# Altium schematic sheet Inresol_grid_inverter_Main.SchDoc(Inresol_grid_inverter_Main)
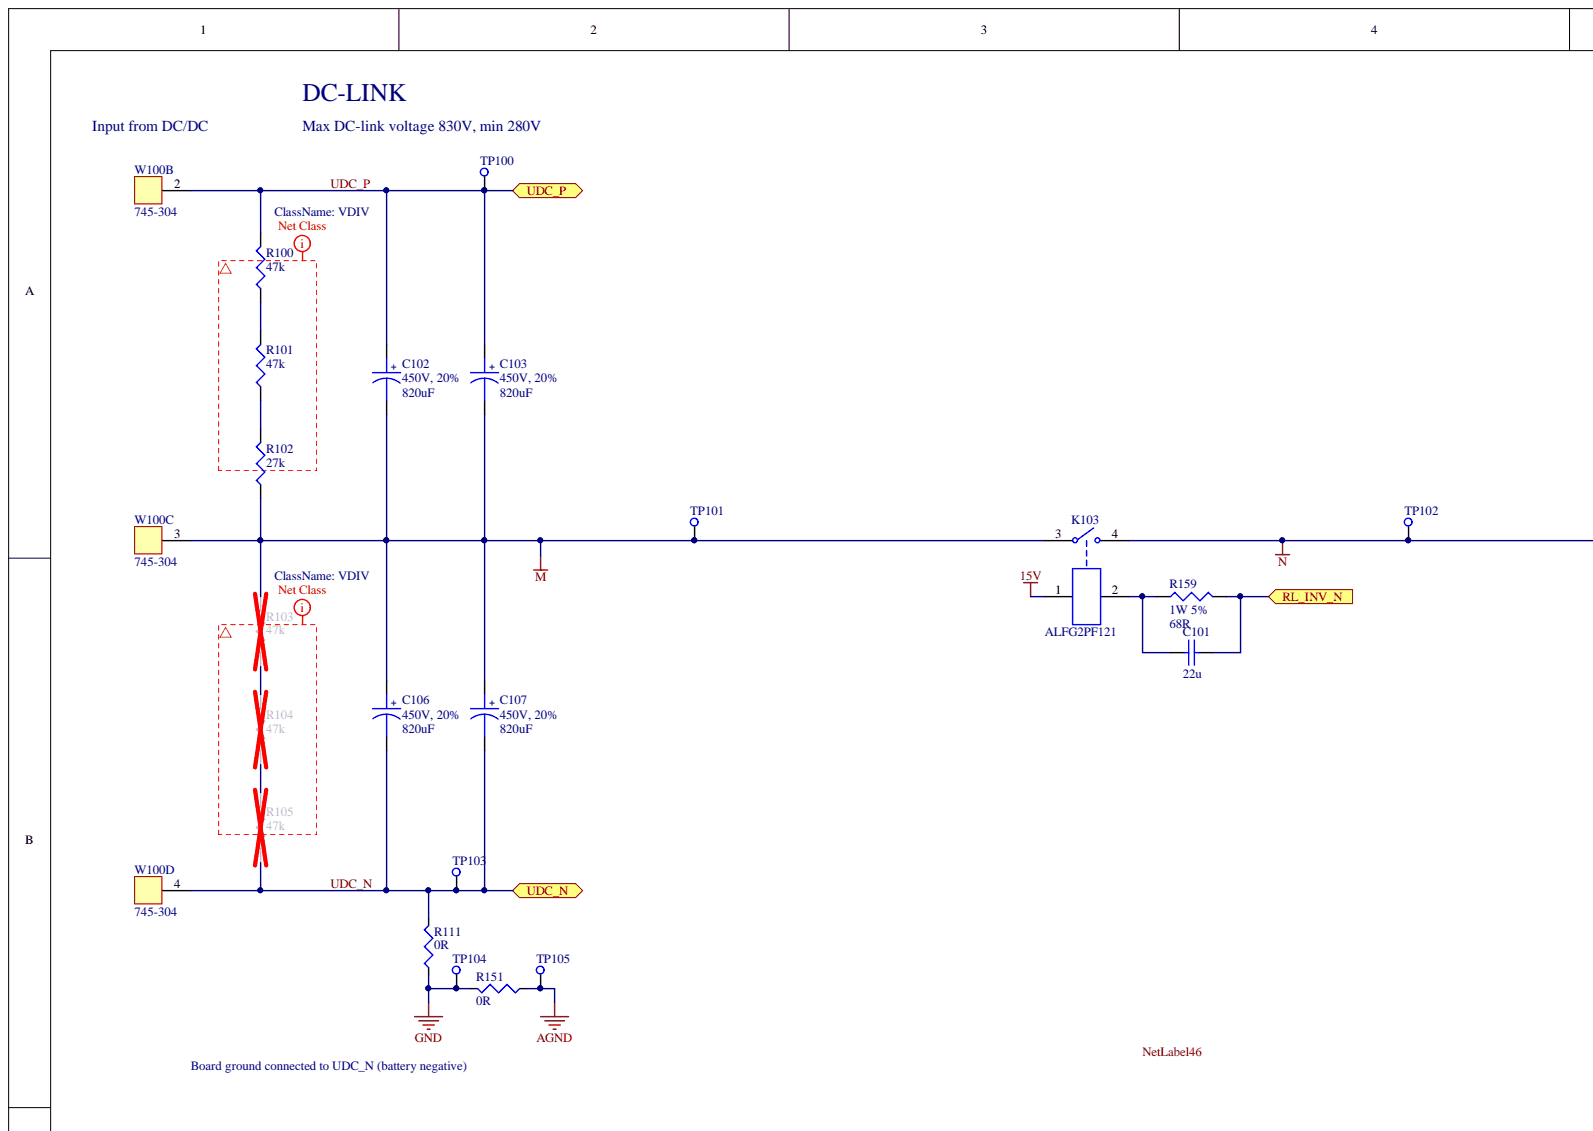
[diagram p0: Inresol_grid_inverter_Main.SchDoc, top left]
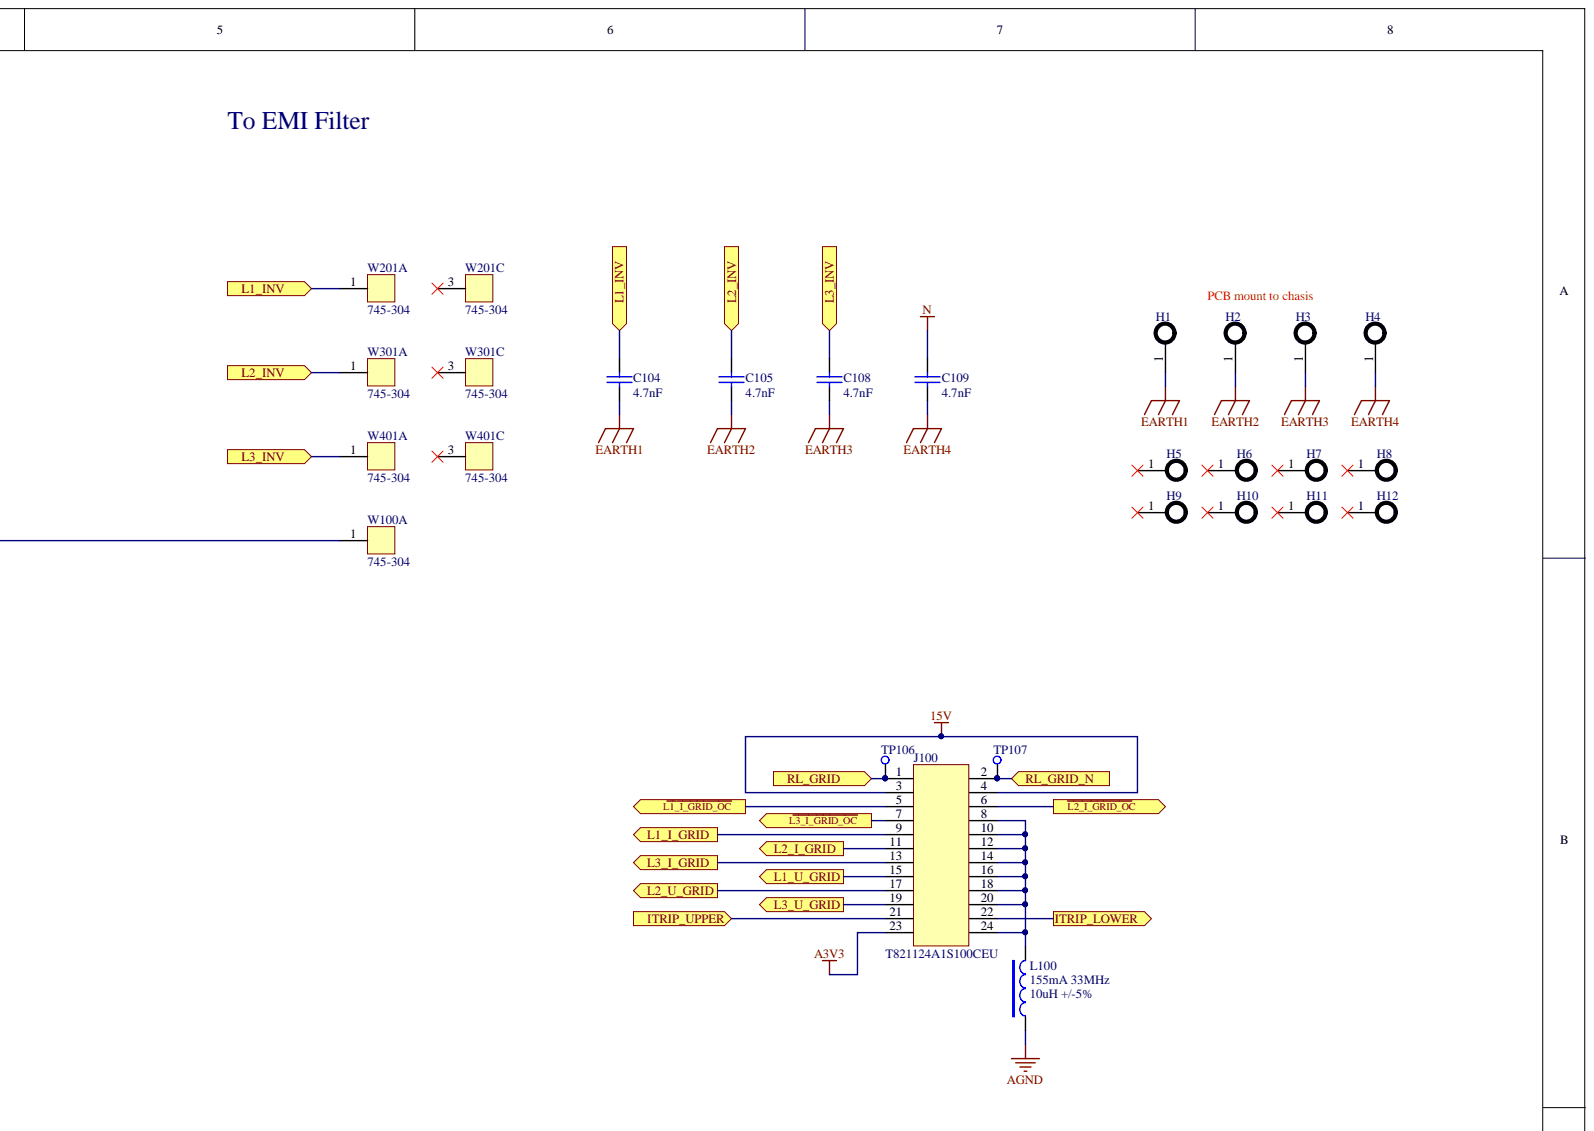
[diagram p1: Inresol_grid_inverter_Main.SchDoc, top right]
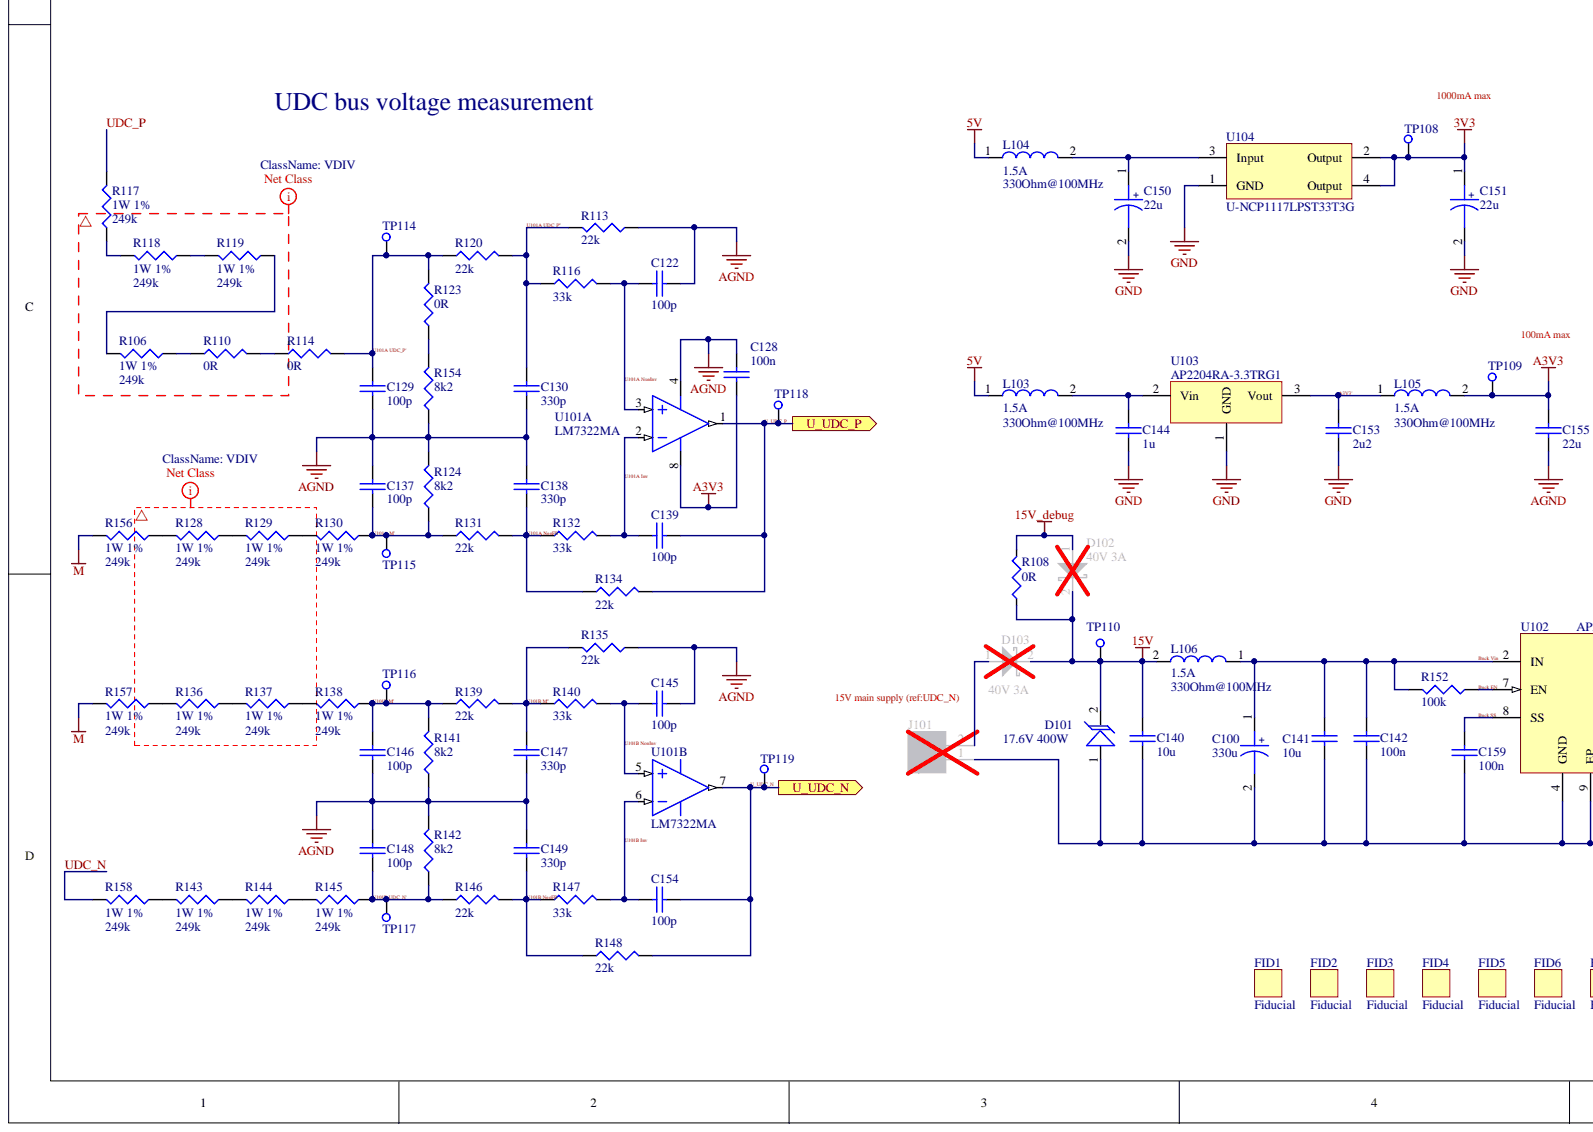
[diagram p2: Inresol_grid_inverter_Main.SchDoc, bottom left]
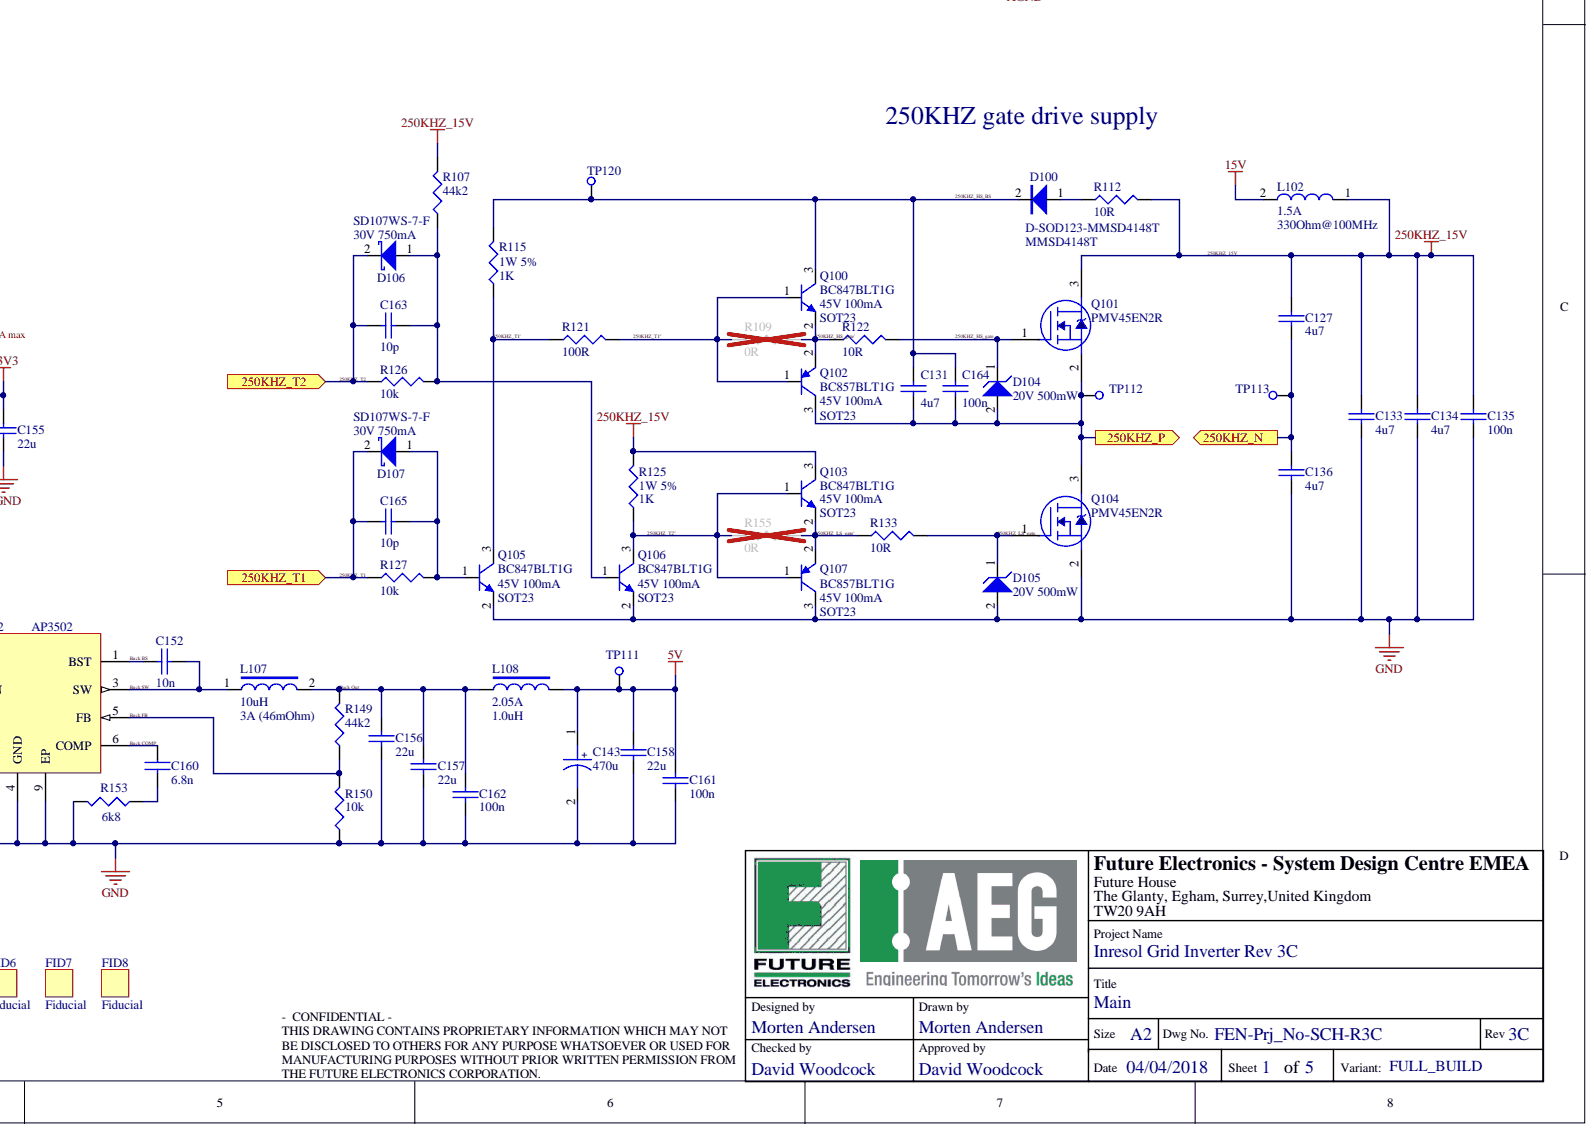
[diagram p3: Inresol_grid_inverter_Main.SchDoc, bottom right]

## Parts
C100  value 330u  [C-SM_R8x10]  (hidden comment: C-330uF-25V-Elec-8x10-EMEA-06-09-2017)
C101  value 22u  [1210]  (hidden comment: C-22uF-25V-Cer-1210)
C102  value 820uF  Rating: 450V, 20%  [C-R40x60_4pin_Snap-In]  (hidden comment: C-820uF-450V-Elec-40x60-EMEA-19-10-2016)
C103  value 820uF  Rating: 450V, 20%  [C-R40x60_4pin_Snap-In]  (hidden comment: C-820uF-450V-Elec-40x60-EMEA-19-10-2016)
C104  value 4.7nF  [C-12.5x5_LS10]  (hidden comment: C-4.7nF-300V-Y2)
C105  value 4.7nF  [C-12.5x5_LS10]  (hidden comment: C-4.7nF-300V-Y2)
C106  value 820uF  Rating: 450V, 20%  [C-R40x60_4pin_Snap-In]  (hidden comment: C-820uF-450V-Elec-40x60-EMEA-19-10-2016)
C107  value 820uF  Rating: 450V, 20%  [C-R40x60_4pin_Snap-In]  (hidden comment: C-820uF-450V-Elec-40x60-EMEA-19-10-2016)
C108  value 4.7nF  [C-12.5x5_LS10]  (hidden comment: C-4.7nF-300V-Y2)
C109  value 4.7nF  [C-12.5x5_LS10]  (hidden comment: C-4.7nF-300V-Y2)
C122  value 100p  [0603]  (hidden comment: C-100pF-25V-Cer-0603)
C127  value 4u7  [1206]  (hidden comment: C-4.7uF-50V-Cer-1206-emea-8-10-15)
C128  value 100n  [0603]  (hidden comment: C-100nF-50V-Cer-0603)
C129  value 100p  [0603]  (hidden comment: C-100pF-25V-Cer-0603)
C130  value 330p  [0603]  (hidden comment: C-330pF-25V-Cer-0603)
C131  value 4u7  [1206]  (hidden comment: C-4.7uF-50V-Cer-1206-emea-8-10-15)
C133  value 4u7  [1206]  (hidden comment: C-4.7uF-50V-Cer-1206-emea-8-10-15)
C134  value 4u7  [1206]  (hidden comment: C-4.7uF-50V-Cer-1206-emea-8-10-15)
C135  value 100n  [0603]  (hidden comment: C-100nF-50V-Cer-0603)
C136  value 4u7  [1206]  (hidden comment: C-4.7uF-50V-Cer-1206-emea-8-10-15)
C137  value 100p  [0603]  (hidden comment: C-100pF-25V-Cer-0603)
C138  value 330p  [0603]  (hidden comment: C-330pF-25V-Cer-0603)
C139  value 100p  [0603]  (hidden comment: C-100pF-25V-Cer-0603)
C140  value 10u  [1206]  (hidden comment: C-10uF-50V-Cer-1206)
C141  value 10u  [1206]  (hidden comment: C-10uF-50V-Cer-1206)
C142  value 100n  [0603]  (hidden comment: C-100nF-50V-Cer-0603)
C143  value 470u  [C-SM_R8x10]  (hidden comment: C-470uF-16V-Elec-8x10-EMEA-28-10-2016)
C144  value 1u  [0603]  (hidden comment: C-1uF-25V-Cer-0603)
C145  value 100p  [0603]  (hidden comment: C-100pF-25V-Cer-0603)
C146  value 100p  [0603]  (hidden comment: C-100pF-25V-Cer-0603)
C147  value 330p  [0603]  (hidden comment: C-330pF-25V-Cer-0603)
C148  value 100p  [0603]  (hidden comment: C-100pF-25V-Cer-0603)
C149  value 330p  [0603]  (hidden comment: C-330pF-25V-Cer-0603)
C150  value 22u  [1210(C3225)L-Tant]  (hidden comment: C-22uF-16V-Tant-1210)
C151  value 22u  [1210(C3225)L-Tant]  (hidden comment: C-22uF-16V-Tant-1210)
C152  value 10n  [1206]  (hidden comment: C-10nF-50V-Cer-1206)
C153  value 2u2  [0805]  (hidden comment: C-2.2uF-25V-Cer-0805-emea-8-10-15)
C154  value 100p  [0603]  (hidden comment: C-100pF-25V-Cer-0603)
C155  value 22u  [1206]  (hidden comment: C-22uF-10V-Cer-1206)
C156  value 22u  [1206]  (hidden comment: C-22uF-10V-Cer-1206)
C157  value 22u  [1206]  (hidden comment: C-22uF-10V-Cer-1206)
C158  value 22u  [1206]  (hidden comment: C-22uF-10V-Cer-1206)
C159  value 100n  [0603]  (hidden comment: C-100nF-50V-Cer-0603)
C160  value 6.8n  [0603]  (hidden comment: C-6.8nF-50V-Cer-0603-EMEA-21-07-16)
C161  value 100n  [0603]  (hidden comment: C-100nF-50V-Cer-0603)
C162  value 100n  [0603]  (hidden comment: C-100nF-50V-Cer-0603)
C163  value 10p  [0603]  (hidden comment: C-10pF-10V-Cer-0603)
C164  value 100n  [0603]  (hidden comment: C-100nF-50V-Cer-0603)
C165  value 10p  [0603]  (hidden comment: C-10pF-10V-Cer-0603)
D100  D-SOD123-MMSD4148T  value MMSD4148T  [SOD123]
D101  D-SMA-SMAJ15A-EMEA-06-09-2017  [SMA]  (value not drawn)
D102  Rating: 40V 3A  [SMA]  (hidden comment: D-SMA-MBRA340T3G)
D103  Rating: 40V 3A  [SMA]  (hidden comment: D-SMA-MBRA340T3G)
D104  Rating: 20V 500mW  [SOD123]  (hidden comment: D-SOD123-MMSZ5250B-20V-EMEA-19-05-16)
D105  Rating: 20V 500mW  [SOD123]  (hidden comment: D-SOD123-MMSZ5250B-20V-EMEA-19-05-16)
D106  Rating: 30V 750mA  [SOD323]  (hidden comment: D-SOD323-SD107WS-7-F)
D107  MFR PN: SD107WS-7-F  Rating: 30V 750mA  [SOD323]  (hidden comment: D-SOD323-SD107WS-7-F)
FID1  W-Fiducial-1mm-emea-21-7-15  [Fiducial]  (value not drawn)
FID2  W-Fiducial-1mm-emea-21-7-15  [Fiducial]  (value not drawn)
FID3  W-Fiducial-1mm-emea-21-7-15  [Fiducial]  (value not drawn)
FID4  W-Fiducial-1mm-emea-21-7-15  [Fiducial]  (value not drawn)
FID5  W-Fiducial-1mm-emea-21-7-15  [Fiducial]  (value not drawn)
FID6  W-Fiducial-1mm-emea-21-7-15  [Fiducial]  (value not drawn)
FID7  W-Fiducial-1mm-emea-21-7-15  [Fiducial]  (value not drawn)
FID8  W-Fiducial-1mm-emea-21-7-15  [Fiducial]  (value not drawn)
H1  W-Mounting Hole-non plated-M4  [W-THRU-HOLE-non plated-M4]  (value not drawn)  (no BOM)
H2  W-Mounting Hole-non plated-M4  [W-THRU-HOLE-non plated-M4]  (value not drawn)  (no BOM)
H3  W-Mounting Hole-non plated-M4  [W-THRU-HOLE-non plated-M4]  (value not drawn)  (no BOM)
H4  W-Mounting Hole-non plated-M4  [W-THRU-HOLE-non plated-M4]  (value not drawn)  (no BOM)
H5  W-Mounting Hole-non plated-M4  [W-THRU-HOLE-non plated-M4]  (value not drawn)  (no BOM)
H6  W-Mounting Hole-non plated-M4  [W-THRU-HOLE-non plated-M4]  (value not drawn)  (no BOM)
H7  W-Mounting Hole-non plated-M4  [W-THRU-HOLE-non plated-M4]  (value not drawn)  (no BOM)
H8  W-Mounting Hole-non plated-M4  [W-THRU-HOLE-non plated-M4]  (value not drawn)  (no BOM)
H9  W-Mounting Hole-non plated-M4  [W-THRU-HOLE-non plated-M4]  (value not drawn)  (no BOM)
H10  W-Mounting Hole-non plated-M4  [W-THRU-HOLE-non plated-M4]  (value not drawn)  (no BOM)
H11  W-Mounting Hole-non plated-M4  [W-THRU-HOLE-non plated-M4]  (value not drawn)  (no BOM)
H12  W-Mounting Hole-non plated-M4  [W-THRU-HOLE-non plated-M4]  (value not drawn)  (no BOM)
J100  MFR PN: T821124A1S100CEU  [HDR100-2X10-TH-KEYED]  (hidden comment: J-HDR100-2x12-TH-KEYED)
J101  J-5.08MM-TERMINAL-2-WAY-282837-2-4-9-15EMEA  [TERMINAL-100MIL-4PIN-EMEA]  (value not drawn)
K103  MFR PN: ALFG2PF121  (hidden comment: K-Panasonic-Relay-SPST-NO-35A-12V-EMEA-07-09-2017)
L100  value 10uH +/-5%  Rating: 155mA 33MHz  [2.5x2mm]  (hidden comment: L-IND2.5X2-10uH-155mA-EMEA_20122017)
L102  value 330Ohm@100MHz  Rating: 1.5A  [0805[2012]]  (hidden comment: 330 Ohm 1.5A)
L103  value 330Ohm@100MHz  Rating: 1.5A  [0805[2012]]  (hidden comment: 330 Ohm 1.5A)
L104  value 330Ohm@100MHz  Rating: 1.5A  [0805[2012]]  (hidden comment: 330 Ohm 1.5A)
L105  value 330Ohm@100MHz  Rating: 1.5A  [0805[2012]]  (hidden comment: 330 Ohm 1.5A)
L106  value 330Ohm@100MHz  Rating: 1.5A  [0805[2012]]  (hidden comment: 330 Ohm 1.5A)
L107  value 10uH  Rating: 3A (46mOhm)  [INDSMD6x6]  (hidden comment: L-INDSMD6X6-10uH_3A)
L108  value 1.0uH  Rating: 2.05A  [tbd]  (hidden comment: L-IND30X30-1uH-2.05A-emea-12-11-15)
Q100  value BC847BLT1G  MFR PN: BC847BLT1G  [SOT23]  (hidden comment: Q-SOT23-BC847BLT1G)
Q101  MFR PN: PMV45EN2R  [SOT23]  (hidden comment: Q-PMV45EN2R)
Q102  value BC857BLT1G  MFR PN: BC857BLT1G  Rating: 45V 100mA  [SOT23]  (hidden comment: Q-SOT23-BC857BLT1G)
Q103  value BC847BLT1G  MFR PN: BC847BLT1G  Rating: 45V 100mA  [SOT23]  (hidden comment: Q-SOT23-BC847BLT1G)
Q104  MFR PN: PMV45EN2R  [SOT23]  (hidden comment: Q-PMV45EN2R)
Q105  value BC847BLT1G  MFR PN: BC847BLT1G  Rating: 45V 100mA  [SOT23]  (hidden comment: Q-SOT23-BC847BLT1G)
Q106  value BC847BLT1G  MFR PN: BC847BLT1G  Rating: 45V 100mA  [SOT23]  (hidden comment: Q-SOT23-BC847BLT1G)
Q107  value BC857BLT1G  MFR PN: BC857BLT1G  Rating: 45V 100mA  [SOT23]  (hidden comment: Q-SOT23-BC857BLT1G)
R100  value 47k  [2512]  (hidden comment: R-47k-1W-2512-EMEA-07-09-2017)
R101  value 47k  [2512]  (hidden comment: R-47k-1W-2512-EMEA-07-09-2017)
R102  value 27k  [2512]  (hidden comment: R-27k-1W-2512-EMEA-07-09-2017)
R103  value 47k  [2512]  (hidden comment: R-47k-1W-2512-EMEA-07-09-2017)
R104  value 47k  [2512]  (hidden comment: R-47k-1W-2512-EMEA-07-09-2017)
R105  value 47k  [2512]  (hidden comment: R-47k-1W-2512-EMEA-07-09-2017)
R106  value 249k  Rating: 1W 1%  [2512]  (hidden comment: R-249k-1W-2512)
R107  value 44k2  [0603]  (hidden comment: R-44.2k-100mW -0603)
R108  value 0R  [1206]  (hidden comment: R-0R-250mW-1206)
R109  value 0R  [0603]  (hidden comment: R-0R-100mW-0603)
R110  value 0R  [2512]  (hidden comment: R-0R-1W-2512)
R111  value 0R  [1206]  (hidden comment: R-0R-250mW-1206)
R112  value 10R  [2512]  (hidden comment: R-10R-1W-2512-EMEA-07-09-2017)
R113  value 22k  [0603]  (hidden comment: R-22k-100mW-0603)
R114  value 0R  [2512]  (hidden comment: R-0R-1W-2512)
R115  value 1K  Rating: 1W 5%  [2512]  (hidden comment: R-1K-1W-2512-EMEA)
R116  value 33k  [0603]  (hidden comment: R-33k-100mW-0603)
R117  value 249k  Rating: 1W 1%  [2512]  (hidden comment: R-249k-1W-2512)
R118  value 249k  Rating: 1W 1%  [2512]  (hidden comment: R-249k-1W-2512)
R119  value 249k  Rating: 1W 1%  [2512]  (hidden comment: R-249k-1W-2512)
R120  value 22k  [0603]  (hidden comment: R-22k-100mW-0603)
R121  value 100R  [0603]  (hidden comment: R-100R-100mW-0603)
R122  value 10R  [2512]  (hidden comment: R-10R-1W-2512-EMEA-07-09-2017)
R123  value 0R  [0603]  (hidden comment: R-0R-100mW-0603)
R124  value 8k2  [0603]  (hidden comment: R-8.2k-100mW-0603)
R125  value 1K  Rating: 1W 5%  [2512]  (hidden comment: R-1K-1W-2512-EMEA)
R126  value 10k  [0603]  (hidden comment: R-10k-100mW-0603)
R127  value 10k  [0603]  (hidden comment: R-10k-100mW-0603)
R128  value 249k  Rating: 1W 1%  [2512]  (hidden comment: R-249k-1W-2512)
R129  value 249k  Rating: 1W 1%  [2512]  (hidden comment: R-249k-1W-2512)
R130  value 249k  Rating: 1W 1%  [2512]  (hidden comment: R-249k-1W-2512)
R131  value 22k  [0603]  (hidden comment: R-22k-100mW-0603)
R132  value 33k  [0603]  (hidden comment: R-33k-100mW-0603)
R133  value 10R  [2512]  (hidden comment: R-10R-1W-2512-EMEA-07-09-2017)
R134  value 22k  [0603]  (hidden comment: R-22k-100mW-0603)
R135  value 22k  [0603]  (hidden comment: R-22k-100mW-0603)
R136  value 249k  Rating: 1W 1%  [2512]  (hidden comment: R-249k-1W-2512)
R137  value 249k  Rating: 1W 1%  [2512]  (hidden comment: R-249k-1W-2512)
R138  value 249k  Rating: 1W 1%  [2512]  (hidden comment: R-249k-1W-2512)
R139  value 22k  [0603]  (hidden comment: R-22k-100mW-0603)
R140  value 33k  [0603]  (hidden comment: R-33k-100mW-0603)
R141  value 8k2  [0603]  (hidden comment: R-8.2k-100mW-0603)
R142  value 8k2  [0603]  (hidden comment: R-8.2k-100mW-0603)
R143  value 249k  Rating: 1W 1%  [2512]  (hidden comment: R-249k-1W-2512)
R144  value 249k  Rating: 1W 1%  [2512]  (hidden comment: R-249k-1W-2512)
R145  value 249k  Rating: 1W 1%  [2512]  (hidden comment: R-249k-1W-2512)
R146  value 22k  [0603]  (hidden comment: R-22k-100mW-0603)
R147  value 33k  [0603]  (hidden comment: R-33k-100mW-0603)
R148  value 22k  [0603]  (hidden comment: R-22k-100mW-0603)
R149  value 44k2  [0603]  (hidden comment: R-44.2k-100mW -0603)
R150  value 10k  [0603]  (hidden comment: R-10k-100mW-0603)
R151  value 0R  [1206]  (hidden comment: R-0R-250mW-1206)
R152  value 100k  [0603]  (hidden comment: R-100k-100mW-0603)
R153  value 6k8  [0603]  (hidden comment: R-6.8k-100mW-0603)
R154  value 8k2  [0603]  (hidden comment: R-8.2k-100mW-0603)
R155  value 0R  [0603]  (hidden comment: R-0R-100mW-0603)
R156  value 249k  Rating: 1W 1%  [2512]  (hidden comment: R-249k-1W-2512)
R157  value 249k  Rating: 1W 1%  [2512]  (hidden comment: R-249k-1W-2512)
R158  value 249k  Rating: 1W 1%  [2512]  (hidden comment: R-249k-1W-2512)
R159  value 68R  Rating: 1W 5%  [2512]  (hidden comment: R-68R-1W-2512)
TP100  TP-TEST POINT-LOOP WT-EMEA-15-10-15  [SMD test point round]  (value not drawn)  (no BOM)
TP101  TP-TEST POINT-LOOP WT-EMEA-15-10-15  [SMD test point round]  (value not drawn)  (no BOM)
TP102  TP-TEST POINT-LOOP WT-EMEA-15-10-15  [SMD test point round]  (value not drawn)  (no BOM)
TP103  TP-TEST POINT-LOOP WT-EMEA-15-10-15  [SMD test point round]  (value not drawn)  (no BOM)
TP104  TP-TEST POINT-LOOP WT-EMEA-15-10-15  [SMD test point round]  (value not drawn)  (no BOM)
TP105  TP-TEST POINT-LOOP WT-EMEA-15-10-15  [SMD test point round]  (value not drawn)  (no BOM)
TP106  TP-TEST POINT-LOOP WT-EMEA-15-10-15  [SMD test point round]  (value not drawn)  (no BOM)
TP107  TP-TEST POINT-LOOP WT-EMEA-15-10-15  [SMD test point round]  (value not drawn)  (no BOM)
TP108  TP-TEST POINT-LOOP WT-EMEA-15-10-15  [SMD test point round]  (value not drawn)  (no BOM)
TP109  TP-TEST POINT-LOOP WT-EMEA-15-10-15  [SMD test point round]  (value not drawn)  (no BOM)
TP110  TP-TEST POINT-LOOP WT-EMEA-15-10-15  [SMD test point round]  (value not drawn)  (no BOM)
TP111  TP-TEST POINT-LOOP WT-EMEA-15-10-15  [SMD test point round]  (value not drawn)  (no BOM)
TP112  TP-TEST POINT-LOOP WT-EMEA-15-10-15  [SMD test point round]  (value not drawn)  (no BOM)
TP113  TP-TEST POINT-LOOP WT-EMEA-15-10-15  [SMD test point round]  (value not drawn)  (no BOM)
TP114  TP-TEST POINT-LOOP WT-EMEA-15-10-15  [SMD test point round]  (value not drawn)  (no BOM)
TP115  TP-TEST POINT-LOOP WT-EMEA-15-10-15  [SMD test point round]  (value not drawn)  (no BOM)
TP116  TP-TEST POINT-LOOP WT-EMEA-15-10-15  [SMD test point round]  (value not drawn)  (no BOM)
TP117  TP-TEST POINT-LOOP WT-EMEA-15-10-15  [SMD test point round]  (value not drawn)  (no BOM)
TP118  TP-TEST POINT-LOOP WT-EMEA-15-10-15  [SMD test point round]  (value not drawn)  (no BOM)
TP119  TP-TEST POINT-LOOP WT-EMEA-15-10-15  [SMD test point round]  (value not drawn)  (no BOM)
TP120  TP-TEST POINT-LOOP WT-EMEA-15-10-15  [SMD test point round]  (value not drawn)  (no BOM)
U101  value LM7322MA  MFR PN: LM7322MA  [SO8]  (hidden comment: U-SOIC8-LM7322MA-EMEA)  (parts A,B)
U102  value AP3502  [SO8]  (hidden comment: U-SO8-AP3502EMTR-G1-EMEA-23-08-2017)
U103  Future PN (TR): AP2204RA-3.3TRG1  [SOT89]  (hidden comment: U-AP2204RA-3.3TRG1-EMEA-30-06-16)
U104  U-NCP1117LPST33T3G  [SOT223]
W100  J-WAGO_745-304_blocks  [745-304]  (value not drawn)  (parts A,B,C,D)
W201  J-WAGO_745-304_blocks  [745-304]  (value not drawn)  (parts A,C)
W301  J-WAGO_745-304_blocks  [745-304]  (value not drawn)  (parts A,C)
W401  J-WAGO_745-304_blocks  [745-304]  (value not drawn)  (parts A,C)

## Ports
250KHZ_N, 250KHZ_P, 250KHZ_T1, 250KHZ_T2, ITRIP_LOWER, ITRIP_UPPER, L1_I_GRID, L1_INV, L1_U_GRID, L2_I_GRID, L2_INV, L2_U_GRID, L3_I_GRID, L3_INV, L3_U_GRID, RL_GRID, RL_GRID_N, RL_INV_N, U_UDC_N, U_UDC_P, UDC_N, UDC_P, ~{L1_I_GRID_OC}, ~{L2_I_GRID_OC}, ~{L3_I_GRID_OC}

## Nets
3V3: C151.1 TP108.1 U104.2(Output) U104.4(Output)
5V: C143.1 C158.1 C161.1 L103.1 L104.1 L108.2 TP111.1
15V: C140.1 D101.2 D102.2 D103.2 J100.3 J100.4 K103.1 L102.2 L106.2 R108.2 TP110.1
15V_DEBUG: D102.1 R108.1
A3V3: C128.2 C155.1 J100.23 L105.2 TP109.1 U101.8
AGND: C122.1 C128.1 C129.2 C130.2 C137.1 C138.1 C145.1 C146.2 C147.2 C148.1 C149.1 C155.2 L100.1 R113.1 R124.2 R135.1 R141.1 R142.2 R151.1 R154.1 TP105.1 U101.4
EARTH1: C104.2 H1.1
EARTH2: C105.2 H2.1
EARTH3: C108.2 H3.1
EARTH4: C109.2 H4.1
GND: C100.2 C133.1 C134.1 C135.2 C136.1 C140.2 C141.2 C142.2 C143.2 C144.2 C150.2 C151.2 C153.1 C156.2 C157.2 C158.2 C159.2 C161.2 C162.2 D101.1 D105.2 J101.1 Q104.2 Q105.2 Q106.2 Q107.3 R111.1 R150.1 R151.2 R153.2 TP104.1 U102.4(GND) U102.9(EP) U103.1 U104.1(GND)
M: C102.2 C103.2 C106.1 C107.1 K103.3 R102.1 R103.2 R156.2 R157.2 TP101.1 W100.3
N: C109.1 K103.4 TP102.1 W100.1
250KHZ_15V: C127.2 C133.2 C134.2 C135.1 L102.1 Q101.3 Q103.3 R107.2 R112.2 R125.2
250KHZ_HS_BS: C131.2 C164.1 D100.2 Q100.3 R115.2 TP120.1
250KHZ_HS_GATE: D104.1 Q101.1 R122.2
250KHZ_HS_GATE': Q100.2 Q102.2 R109.2 R122.1
250KHZ_LS_GATE: D105.1 Q104.1 R133.2
250KHZ_LS_GATE': Q103.2 Q107.2 R133.1 R155.2
250KHZ_N: C127.1 C136.2 TP113.1 ; port 250KHZ_N
250KHZ_P: C131.1 C164.2 D104.2 Q101.2 Q102.3 Q104.3 TP112.1 ; port 250KHZ_P
250KHZ_T1: C165.1 D107.2 R127.1 ; port 250KHZ_T1
250KHZ_T1': Q105.3 R115.1 R121.1
250KHZ_T1'': Q100.1 Q102.1 R109.1 R121.2
250KHZ_T2: C163.1 D106.2 R126.1 ; port 250KHZ_T2
250KHZ_T2'': Q103.1 Q106.3 Q107.1 R125.1 R155.1
A3V3': C153.2 L105.1 U103.3
BUCK BS: C152.1 U102.1(BST)
BUCK COMP: C160.1 U102.6(COMP)
BUCK EN: R152.1 U102.7(EN)
BUCK FB: R149.1 R150.2 U102.5(FB)
BUCK OUT: C156.1 C157.1 C162.1 L107.2 L108.1 R149.2
BUCK SS: C159.1 U102.8(SS)
BUCK SW: C152.2 L107.1 U102.3(SW)
BUCK VIN: C100.1 C141.1 C142.1 L106.1 R152.2 U102.2(IN)
ITRIP_LOWER: J100.22 ; port ITRIP_LOWER
ITRIP_UPPER: J100.21 ; port ITRIP_UPPER
L1_I_GRID: J100.9 ; port L1_I_GRID
L1_INV: C104.1 W201.1 ; port L1_INV
L1_U_GRID: J100.15 ; port L1_U_GRID
L2_I_GRID: J100.11 ; port L2_I_GRID
L2_INV: C105.1 W301.1 ; port L2_INV
L2_U_GRID: J100.17 ; port L2_U_GRID
L3_I_GRID: J100.13 ; port L3_I_GRID
L3_INV: C108.1 W401.1 ; port L3_INV
L3_U_GRID: J100.19 ; port L3_U_GRID
~{L1_I_GRID_OC}: J100.5 ; port ~{L1_I_GRID_OC}
~{L2_I_GRID_OC}: J100.6 ; port ~{L2_I_GRID_OC}
~{L3_I_GRID_OC}: J100.7 ; port ~{L3_I_GRID_OC}
RL_GRID: J100.1 TP106.1 ; port RL_GRID
RL_GRID_N: J100.2 TP107.1 ; port RL_GRID_N
RL_INV_N: C101.2 R159.2 ; port RL_INV_N
U101A INV: C139.2 R132.2 U101.2
U101A M': C137.2 R124.1 R130.1 R131.1 TP115.1
U101A NEGFB: C138.2 R131.2 R132.1 R134.1
U101A NONINV: C122.2 R116.2 U101.3
U101A UDC_P': C129.1 R114.2 R120.1 R123.2 TP114.1
U101A UDC_P'': C130.1 R113.2 R116.1 R120.2
U101B INV: C154.2 R147.2 U101.6
U101B M': C146.1 R138.1 R139.1 R141.2 TP116.1
U101B M'': C147.1 R135.2 R139.2 R140.1
U101B NEGFB: C149.2 R146.2 R147.1 R148.1
U101B NONINV: C145.2 R140.2 U101.5
U101B UDC_N': C148.2 R142.1 R145.1 R146.1 TP117.1
U_UDC_N: C154.1 R148.2 TP119.1 U101.7 ; port U_UDC_N
U_UDC_P: C139.1 R134.2 TP118.1 U101.1 ; port U_UDC_P
UDC_N: C106.2 C107.2 R105.1 R111.2 R158.2 TP103.1 W100.4 ; port UDC_N
UDC_P: C102.1 C103.1 R100.2 R117.2 TP100.1 W100.2 ; port UDC_P
NetC101_1: C101.1 K103.2 R159.1
NetC144_1: C144.1 L103.2 U103.2
NetC150_1: C150.1 L104.2 U104.3(Input)
NetC160_2: C160.2 R153.1
NetC163_2: C163.2 D106.1 Q106.1 R107.1 R126.2
NetC165_2: C165.2 D107.1 Q105.1 R127.2
NetD100_1: D100.1 R112.1
NetD103_1: D103.1 J101.2
NetJ100_8: J100.8 J100.10 J100.12 J100.14 J100.16 J100.18 J100.20 J100.24 L100.2
NetR100_1: R100.1 R101.2
NetR101_1: R101.1 R102.2
NetR103_1: R103.1 R104.2
NetR104_1: R104.1 R105.2
NetR106_1: R106.1 R110.1
NetR106_2: R106.2 R119.1
NetR110_2: R110.2 R114.1
NetR117_1: R117.1 R118.2
NetR118_1: R118.1 R119.2
NetR123_1: R123.1 R154.2
NetR128_1: R128.1 R129.2
NetR128_2: R128.2 R156.1
NetR129_1: R129.1 R130.2
NetR136_1: R136.1 R137.2
NetR136_2: R136.2 R157.1
NetR137_1: R137.1 R138.2
NetR143_1: R143.1 R144.2
NetR143_2: R143.2 R158.1
NetR144_1: R144.1 R145.2
N00524:
N00525:
N00526:
N00527:
NETLABEL46:

Not connected: H5 1; H6 1; H7 1; H8 1; H9 1; H10 1; H11 1; H12 1; W201 3; W301 3; W401 3
